# Altium schematic sheet Reed Switches.SchDoc
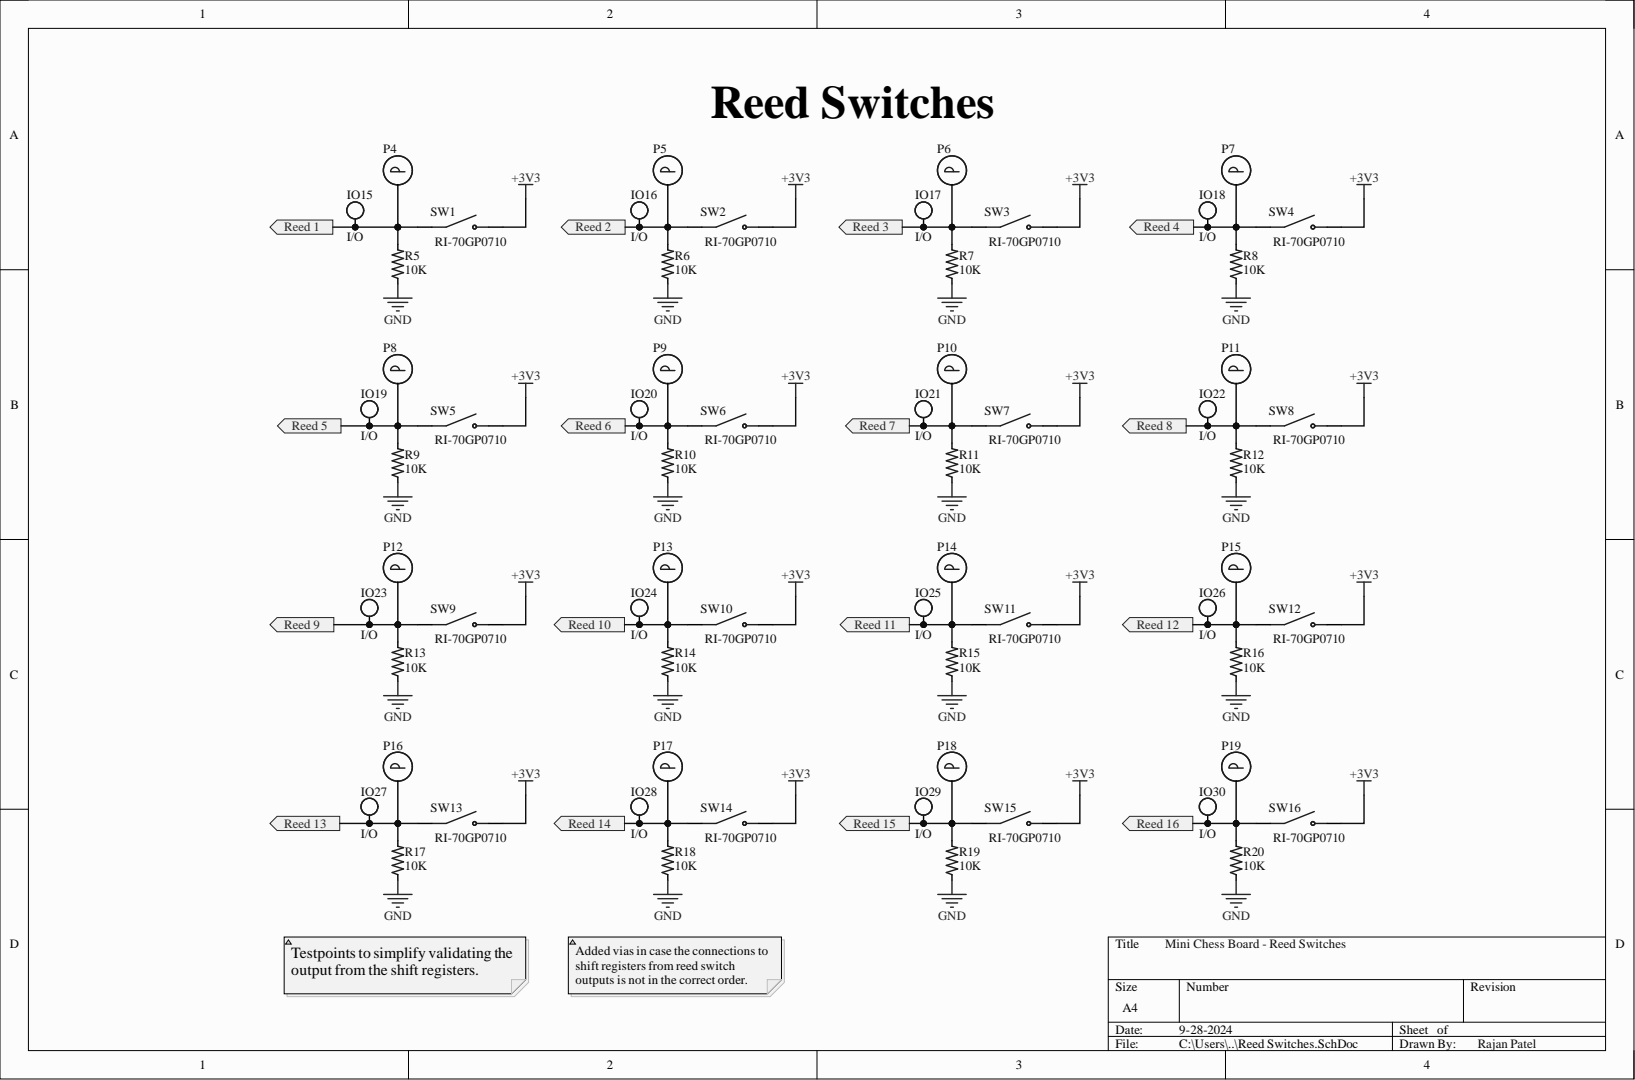
[diagram p0: Reed Switches.SchDoc]

## Parts
IO12  I/O  [I/O]  (value not drawn)
IO13  I/O  [I/O]  (value not drawn)
IO14  I/O  [I/O]  (value not drawn)
IO15  I/O  [I/O]
IO16  I/O  [I/O]
IO17  I/O  [I/O]
IO18  I/O  [I/O]
IO19  I/O  [I/O]
IO20  I/O  [I/O]
IO21  I/O  [I/O]
IO22  I/O  [I/O]
IO23  I/O  [I/O]
IO24  I/O  [I/O]
IO25  I/O  [I/O]
IO26  I/O  [I/O]
IO27  I/O  [I/O]
IO28  I/O  [I/O]
IO29  I/O  [I/O]
IO30  I/O  [I/O]
P4  Probe_5019  [Testpoint]  (value not drawn)
P5  Probe_5019  [Testpoint]  (value not drawn)
P6  Probe_5019  [Testpoint]  (value not drawn)
P7  Probe_5019  [Testpoint]  (value not drawn)
P8  Probe_5019  [Testpoint]  (value not drawn)
P9  Probe_5019  [Testpoint]  (value not drawn)
P10  Probe_5019  [Testpoint]  (value not drawn)
P11  Probe_5019  [Testpoint]  (value not drawn)
P12  Probe_5019  [Testpoint]  (value not drawn)
P13  Probe_5019  [Testpoint]  (value not drawn)
P14  Probe_5019  [Testpoint]  (value not drawn)
P15  Probe_5019  [Testpoint]  (value not drawn)
P16  Probe_5019  [Testpoint]  (value not drawn)
P17  Probe_5019  [Testpoint]  (value not drawn)
P18  Probe_5019  [Testpoint]  (value not drawn)
P19  Probe_5019  [Testpoint]  (value not drawn)
R5  10K  [res 0805]
R6  10K  [res 0805]
R7  10K  [res 0805]
R8  10K  [res 0805]
R9  10K  [res 0805]
R10  10K  [res 0805]
R11  10K  [res 0805]
R12  10K  [res 0805]
R13  10K  [res 0805]
R14  10K  [res 0805]
R15  10K  [res 0805]
R16  10K  [res 0805]
R17  10K  [res 0805]
R18  10K  [res 0805]
R19  10K  [res 0805]
R20  10K  [res 0805]
SW1  RI-70GP0710  [RI-70GP0710]
SW2  RI-70GP0710  [RI-70GP0710]
SW3  RI-70GP0710  [RI-70GP0710]
SW4  RI-70GP0710  [RI-70GP0710]
SW5  RI-70GP0710  [RI-70GP0710]
SW6  RI-70GP0710  [RI-70GP0710]
SW7  RI-70GP0710  [RI-70GP0710]
SW8  RI-70GP0710  [RI-70GP0710]
SW9  RI-70GP0710  [RI-70GP0710]
SW10  RI-70GP0710  [RI-70GP0710]
SW11  RI-70GP0710  [RI-70GP0710]
SW12  RI-70GP0710  [RI-70GP0710]
SW13  RI-70GP0710  [RI-70GP0710]
SW14  RI-70GP0710  [RI-70GP0710]
SW15  RI-70GP0710  [RI-70GP0710]
SW16  RI-70GP0710  [RI-70GP0710]

## Ports
Reed 1, Reed 2, Reed 3, Reed 4, Reed 5, Reed 6, Reed 7, Reed 8, Reed 9, Reed 10, Reed 11, Reed 12, Reed 13, Reed 14, Reed 15, Reed 16

## Nets
+3V3: SW1.2 SW2.2 SW3.2 SW4.2 SW5.2 SW6.2 SW7.2 SW8.2 SW9.2 SW10.2 SW11.2 SW12.2 SW13.2 SW14.2 SW15.2 SW16.2
GND: R5.1 R6.1 R7.1 R8.1 R9.1 R10.1 R11.1 R12.1 R13.1 R14.1 R15.1 R16.1 R17.1 R18.1 R19.1 R20.1
Reed 1: IO15.1 P4.1 R5.2 SW1.1 ; port Reed 1
Reed 2: IO16.1 P5.1 R6.2 SW2.1 ; port Reed 2
Reed 3: IO17.1 P6.1 R7.2 SW3.1 ; port Reed 3
Reed 4: IO18.1 P7.1 R8.2 SW4.1 ; port Reed 4
Reed 5: IO19.1 P8.1 R9.2 SW5.1 ; port Reed 5
Reed 6: IO20.1 P9.1 R10.2 SW6.1 ; port Reed 6
Reed 7: IO21.1 P10.1 R11.2 SW7.1 ; port Reed 7
Reed 8: IO22.1 P11.1 R12.2 SW8.1 ; port Reed 8
Reed 9: IO23.1 P12.1 R13.2 SW9.1 ; port Reed 9
Reed 10: IO24.1 P13.1 R14.2 SW10.1 ; port Reed 10
Reed 11: IO25.1 P14.1 R15.2 SW11.1 ; port Reed 11
Reed 12: IO26.1 P15.1 R16.2 SW12.1 ; port Reed 12
Reed 13: IO27.1 P16.1 R17.2 SW13.1 ; port Reed 13
Reed 14: IO28.1 P17.1 R18.2 SW14.1 ; port Reed 14
Reed 15: IO29.1 P18.1 R19.2 SW15.1 ; port Reed 15
Reed 16: IO30.1 P19.1 R20.2 SW16.1 ; port Reed 16

Not connected: IO12 1; IO13 1; IO14 1

## Library data of the parts
P4-P19 = Probe_5019: Phosphor Bronze Contact Miniature Silver Plated Surface Mount Test Points; Manufacturer: Keystone Electronics; Manufacturer Part Number: 5019; Body Material: Bronze; Category: Connectors; Component Kind: Standard; ComponentLink1Description: https://datasheet.ciiva.com/16318/m60-2p61-16318395.pdf?src-supplier=Future+Electronics; ComponentLink1URL: https://datasheet.ciiva.com/16318/m60-2p61-16318395.pdf?src-supplier=Future+Electronics; ComponentLink2Description: https://datasheet.ciiva.com/16885/70182998-16885945.pdf?src-supplier=Allied+Electronics; ComponentLink2URL: https://datasheet.ciiva.com/16885/70182998-16885945.pdf?src-supplier=Allied+Electronics; ComponentLink3Description: https://datasheet.ciiva.com/14848/m60-2p61-14848437.pdf?src-supplier=Digi-Key; ComponentLink3URL: https://datasheet.ciiva.com/14848/m60-2p61-14848437.pdf?src-supplier=Digi-Key; ComponentLink4Description: https://datasheet.ciiva.com/14848/m60-2p61-14848438.pdf?src-supplier=Digi-Key; ComponentLink4URL: https://datasheet.ciiva.com/14848/m60-2p61-14848438.pdf?src-supplier=Digi-Key; ComponentLink5Description: https://datasheet.ciiva.com/14848/m60-2p61-14848439.pdf?src-supplier=Digi-Key; ComponentLink5URL: https://datasheet.ciiva.com/14848/m60-2p61-14848439.pdf?src-supplier=Digi-Key; Contact Material: Bronze; Contact Plating: Silver; Height: 0.09inch; Lead Free: Lead Free; Material: Bronze; Mount: Surface Mount,PCB; Number of Pins: 1; Package Quantity: 1; Packaging: Tape and Reel; Plating: Silver; Pricing 1: 1=0.12300, 1000=0.12300, 2000=0.11700, 4000=0.11300, 6000=0.11000 (USD); Reach SVHC Compliant: Yes; RoHS: Yes; RoHS Compliant: Yes; Series: 5019; Stock 1: 148000; Supplier 1: Newark; Supplier Part Number 1: 06W4636; Termination: SMD/SMT; Library Name: MiniChessBoardSchLib.SchLib
R5-R20 = Resistor 0805: MF: TE Connectivity; MP: 2-2176053-2; Power_Rating: .25 W; Resistance: .39; Tolerance: 1%; Availability: Unavailable; Component Kind: Standard; EU_RoHS_Compliance: Compliant with Exemptions; Package: 0805; Price: None; SnapEDA_Link: https://www.snapeda.com/parts/2-2176053-2/TE%20Connectivity/view-part/2214511/?ref=snap; Library Name: ChessBoardSchLib.SchLib
SW1-SW16 = RI-70GP0710: Switch Reed Spst-no 500MA 120V; Manufacturer: Comus; Component Kind: Standard; ComponentLink1Description: https://www.comus-intl.com/wp-content/uploads/2018/10/RI-70GP.pdf?src-supplier=Digikey; ComponentLink1URL: https://www.comus-intl.com/wp-content/uploads/2018/10/RI-70GP.pdf?src-supplier=Digikey; Manufacturer Part Number: RI-70GP0710; Pricing: 1=1.05, 10=1.01, 25=0.9496, 100=0.8201, 250=0.7474, 500=0.69488, 2000=0.6464, 4000=0.5858, 6000=0.5454 (USD); RoHS: No; Stock: 52347; Supplier: Digikey; Supplier Part Number: 1835-1025-ND; Library Name: ChessBoardSchLib.SchLib
IO12-IO30 = I/O: Component Kind: Standard; Library Name: MiniChessBoardSchLib.SchLib
